# Altium schematic sheet DC-Motor-DRV.SchDoc(DC-Motor-DRV)
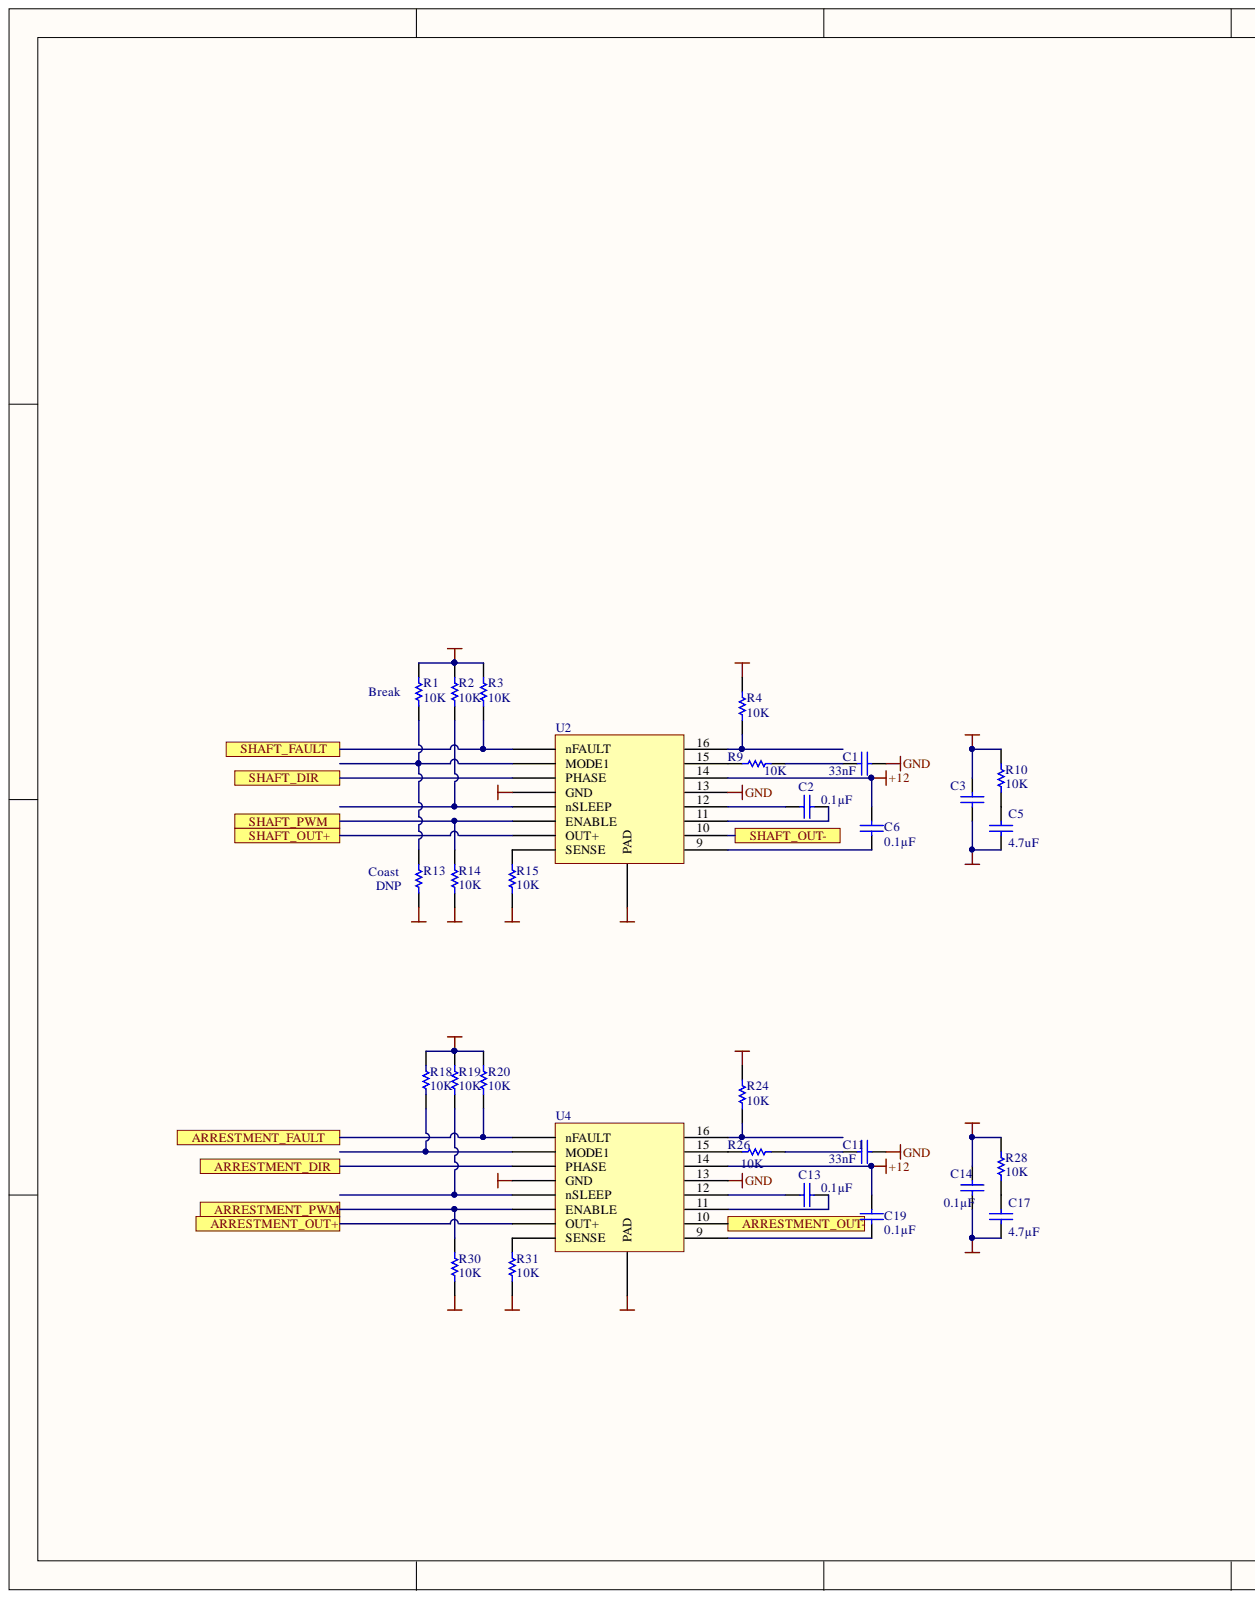
[diagram p0: DC-Motor-DRV.SchDoc, left]
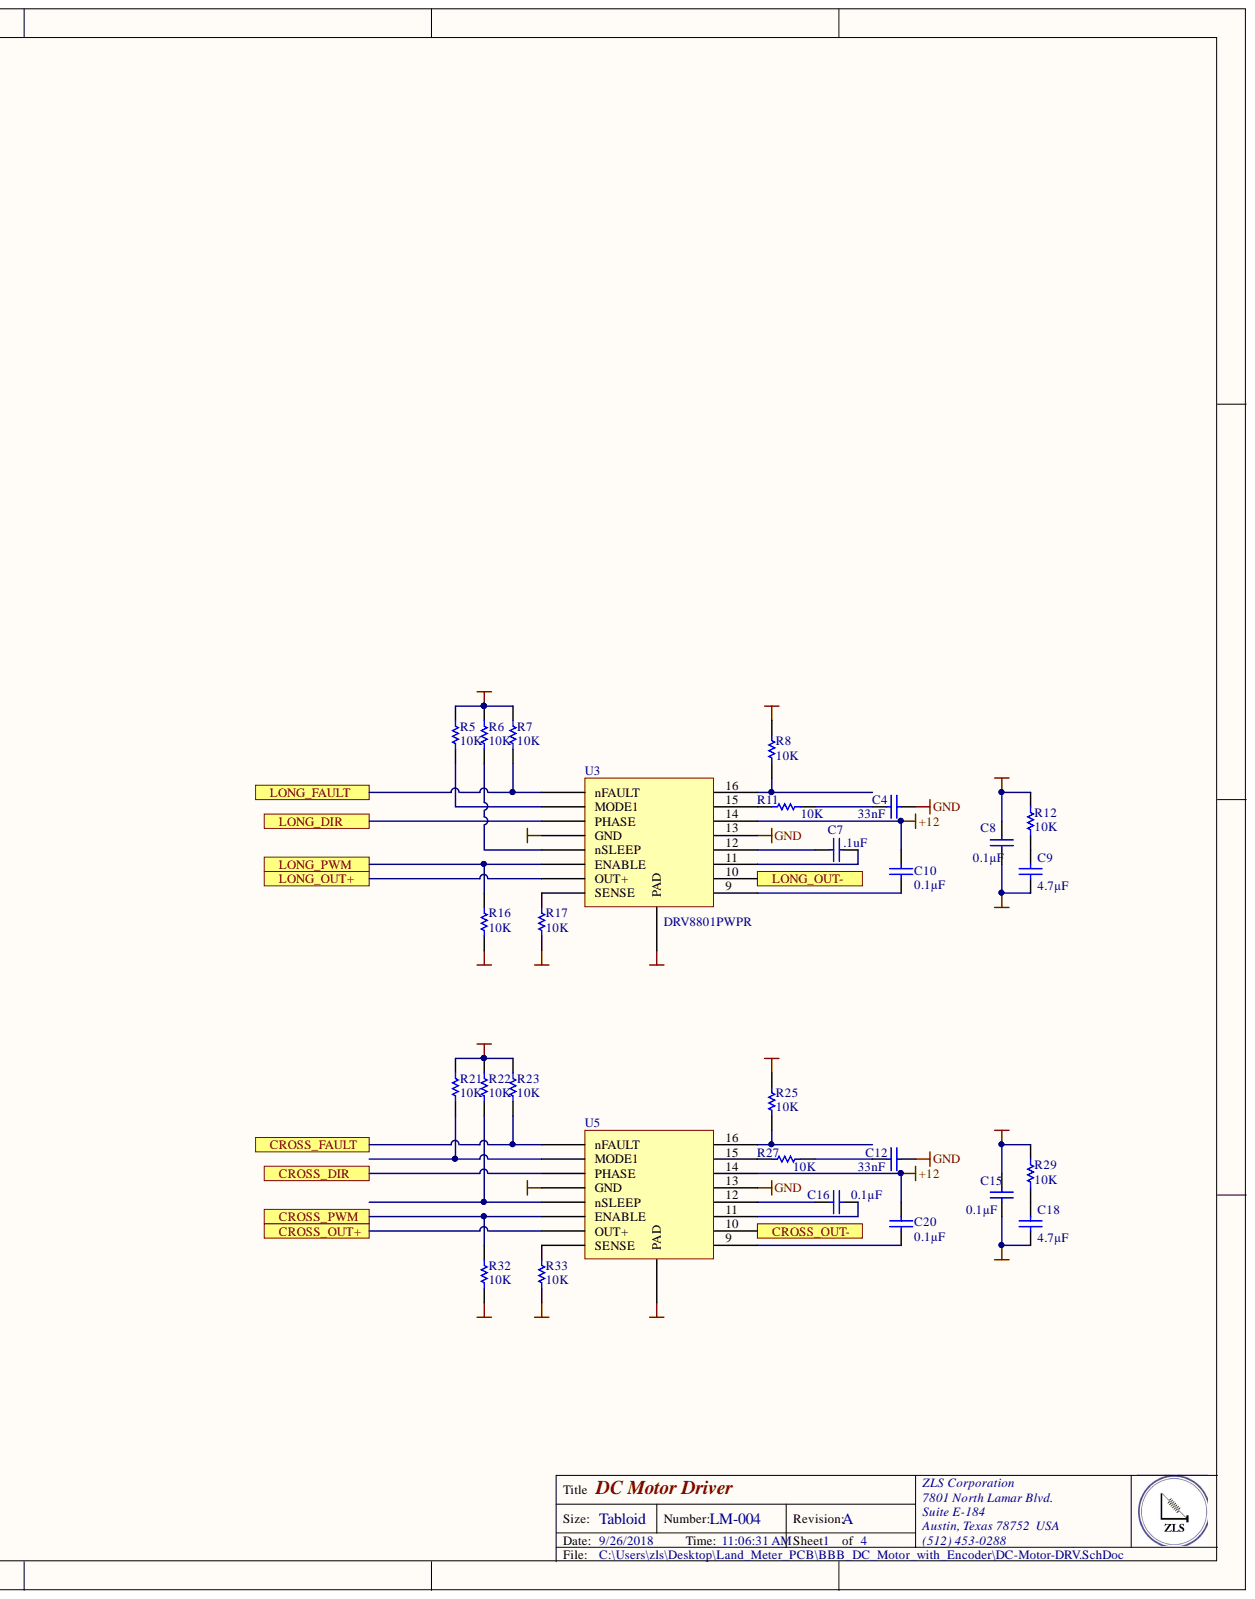
[diagram p1: DC-Motor-DRV.SchDoc, right]

## Parts
C1  33nF  [CAPC0402(1005)60_L]
C2  Capacitance: 0.1µF  [CAPC0805(2012)145_N]  (hidden comment: 0.1uF)
C3  0.1uF  [CAPC0805(2012)145_N]  (value not drawn)
C4  33nF  [CAPC0402(1005)60_L]
C5  4.7uF  [CAPC0805(2012)145_N]
C6  Capacitance: 0.1µF  [CAPC0805(2012)145_N]  (hidden comment: 0.1uF)
C7  .1uF  [CAPC0402(1005)60_N]
C8  Capacitance: 0.1µF  [CAPC0805(2012)145_N]  (hidden comment: .1uF)
C9  Capacitance: 4.7µF  [CAPC0805(2012)145_N]  (hidden comment: 4.7uF)
C10  .1uF  Capacitance: 0.1µF  [CAPC0805(2012)145_N]
C11  33nF  [CAPC0402(1005)60_L]
C12  33nF  [CAPC0402(1005)60_L]
C13  Capacitance: 0.1µF  [CAPC0805(2012)145_N]  (hidden comment: CAP CER 0.1UF 25V X7R 0805)
C14  Capacitance: 0.1µF  [CAPC0805(2012)145_N]  (hidden comment: CAP CER 0.1UF 25V X7R 0805)
C15  Capacitance: 0.1µF  [CAPC0805(2012)145_N]  (hidden comment: 0.1uF)
C16  Capacitance: 0.1µF  [CAPC0805(2012)145_N]  (hidden comment: 0.1uF)
C17  Capacitance: 4.7µF  [CAPC0805(2012)145_N]  (hidden comment: CAP CER 0.1UF 25V X7R 0805)
C18  Capacitance: 4.7µF  [CAPC0805(2012)145_N]  (hidden comment: 4.7uF)
C19  Capacitance: 0.1µF  [CAPC0805(2012)145_N]  (hidden comment: CAP CER 0.1UF 25V X7R 0805)
C20  Capacitance: 0.1µF  [CAPC0805(2012)145_N]  (hidden comment: 0.1uF)
R1  10K  [RESC0805(2012)_L]
R2  10K  [RESC0805(2012)_L]
R3  10K  [RESC0805(2012)_L]
R4  10K  [RESC0805(2012)_L]
R5  10K  [RESC0805(2012)_L]
R6  10K  [RESC0805(2012)_L]
R7  10K  [RESC0805(2012)_L]
R8  10K  [RESC0805(2012)_L]
R9  10K  [RESC0805(2012)_L]
R10  10K  [RESC0805(2012)_L]
R11  10K  [RESC0805(2012)_L]
R12  10K  [RESC0805(2012)_L]
R13  DNP  [RESC0805(2012)_L]
R14  10K  [RESC0805(2012)_L]
R15  10K  [RESC0805(2012)_L]
R16  10K  [RESC0805(2012)_L]
R17  10K  [RESC0805(2012)_L]
R18  10K  [RESC0805(2012)_L]
R19  10K  [RESC0805(2012)_L]
R20  10K  [RESC0805(2012)_L]
R21  10K  [RESC0805(2012)_L]
R22  10K  [RESC0805(2012)_L]
R23  10K  [RESC0805(2012)_L]
R24  10K  [RESC0805(2012)_L]
R25  10K  [RESC0805(2012)_L]
R26  10K  [RESC0805(2012)_L]
R27  10K  [RESC0805(2012)_L]
R28  10K  [RESC0805(2012)_L]
R29  10K  [RESC0805(2012)_L]
R30  10K  [RESC0805(2012)_L]
R31  10K  [RESC0805(2012)_L]
R32  10K  [RESC0805(2012)_L]
R33  10K  [RESC0805(2012)_L]
U2  DRV8801PWPR  [DRV8801PWPR]
U3  DRV8801PWPR  [DRV8801PWPR]
U4  DRV8801PWPR  [DRV8801PWPR]
U5  DRV8801PWPR  [DRV8801PWPR]

## Ports
ARRESTMENT_DIR, ARRESTMENT_FAULT, ARRESTMENT_OUT+, ARRESTMENT_OUT-, ARRESTMENT_PWM, CROSS_DIR, CROSS_FAULT, CROSS_OUT+, CROSS_OUT-, CROSS_PWM, LONG_DIR, LONG_FAULT, LONG_OUT+, LONG_OUT-, LONG_PWM, SHAFT_DIR, SHAFT_FAULT, SHAFT_OUT+, SHAFT_OUT-, SHAFT_PWM

## Nets
+3.3: R1.1 R2.1 R3.1 R4.1 R5.1 R6.1 R7.1 R8.1 R18.1 R19.1 R20.1 R21.1 R22.1 R23.1 R24.1 R25.1
+12: C3.1 C6.2 C8.1 C10.2 C14.1 C15.1 C19.2 C20.2 R10.1 R12.1 R28.1 R29.1 U2.14 U3.14 U4.14 U5.14
GND: C1.2 C3.2 C4.2 C5.2 C8.2 C9.2 C11.2 C12.2 C14.2 C15.2 C17.2 C18.2 R13.2 R14.2 R15.2 R16.2 R17.2 R30.2 R31.2 R32.2 R33.2 U2.4(GND) U2.13 U2.17(PAD) U3.4(GND) U3.13 U3.17(PAD) U4.4(GND) U4.13 U4.17(PAD) U5.4(GND) U5.13 U5.17(PAD)
ARRESTMENT_DIR: U4.3(PHASE) ; port ARRESTMENT_DIR
ARRESTMENT_FAULT: R20.2 U4.1(nFAULT) ; port ARRESTMENT_FAULT
ARRESTMENT_PWM: R30.1 U4.6(ENABLE) ; port ARRESTMENT_PWM
CROSS_DIR: U5.3(PHASE) ; port CROSS_DIR
CROSS_FAULT: R23.2 U5.1(nFAULT) ; port CROSS_FAULT
CROSS_PWM: R32.1 U5.6(ENABLE) ; port CROSS_PWM
LONG_DIR: U3.3(PHASE) ; port LONG_DIR
LONG_FAULT: R7.2 U3.1(nFAULT) ; port LONG_FAULT
LONG_PWM: R16.1 U3.6(ENABLE) ; port LONG_PWM
SHAFT_DIR: U2.3(PHASE) ; port SHAFT_DIR
SHAFT_FAULT: R3.2 U2.1(nFAULT) ; port SHAFT_FAULT
SHAFT_PWM: R14.1 U2.6(ENABLE) ; port SHAFT_PWM
NetC1_1: C1.1 R9.1
NetC2_1: C2.1 U2.12
NetC2_2: C2.2 U2.11
NetC4_1: C4.1 R11.1
NetC5_1: C5.1 R10.2
NetC6_1: C6.1 U2.9
NetC7_1: C7.1 U3.12
NetC7_2: C7.2 U3.11
NetC9_1: C9.1 R12.2
NetC10_1: C10.1 U3.9
NetC11_1: C11.1 R26.1
NetC12_1: C12.1 R27.1
NetC13_1: C13.1 U4.12
NetC13_2: C13.2 U4.11
NetC16_1: C16.1 U5.12
NetC16_2: C16.2 U5.11
NetC17_1: C17.1 R28.2
NetC18_1: C18.1 R29.2
NetC19_1: C19.1 U4.9
NetC20_1: C20.1 U5.9
NetR1_2: R1.2 R13.1 U2.2(MODE1)
NetR2_2: R2.2 U2.5(nSLEEP)
NetR4_2: R4.2 U2.16
NetR5_2: R5.2 U3.2(MODE1)
NetR6_2: R6.2 U3.5(nSLEEP)
NetR8_2: R8.2 U3.16
NetR9_2: R9.2 U2.15
NetR11_2: R11.2 U3.15
NetR15_1: R15.1 U2.8(SENSE)
NetR17_1: R17.1 U3.8(SENSE)
NetR18_2: R18.2 U4.2(MODE1)
NetR19_2: R19.2 U4.5(nSLEEP)
NetR21_2: R21.2 U5.2(MODE1)
NetR22_2: R22.2 U5.5(nSLEEP)
NetR24_2: R24.2 U4.16
NetR25_2: R25.2 U5.16
NetR26_2: R26.2 U4.15
NetR27_2: R27.2 U5.15
NetR31_1: R31.1 U4.8(SENSE)
NetR33_1: R33.1 U5.8(SENSE)
NetU2_7: U2.7(OUT+)
NetU2_10: U2.10
NetU3_7: U3.7(OUT+)
NetU3_10: U3.10
NetU4_7: U4.7(OUT+)
NetU4_10: U4.10
NetU5_7: U5.7(OUT+)
NetU5_10: U5.10

## Library data of the parts
C1 C11 = CMP-1034-00252-1: CAP CER 0.1UF 10V X7R 0402; Manufacturer Part Number: GRM155R71A104KA01D; Manufacturer: Murata Electronics North America; Tolerance: ±10%; Voltage - Rated: 10V; Thickness (Max): 0.022" (0.55mm); Temperature Coefficient: X7R; Supplier Part Number 1: 490-6321-1-ND; Supplier 1: Digi-Key; Stock 1: 2920395; Size / Dimension: 0.039" L x 0.020" W (1.00mm x 0.50mm); RoHS: RoHS Compliant; Ratings: -; Pricing 1: 1=0.1, 10=0.014, 100=0.0063, 500=0.00446, 1000=0.00351, 2500=0.00319, 5000=0.00293 (USD); Part Status: Active; Packaging: Cut Tape (CT); Package / Case: 0402 (1005 Metric); Operating Temperature: -55°C ~ 125°C; Mounting Type: Surface Mount, MLCC; Library Name: Altium Content Vault; Lead Spacing: -; Height - Seated (Max): -; Features: -; ComponentLink1URL: http://www.murata.com/~/media/webrenewal/support/library/catalog/products/capacitor/mlcc/c02e.ashx?la=en-us; ComponentLink1Description: http://www.murata.com/~/media/webrenewal/support/library/catalog/products/capacitor/mlcc/c02e.ashx?la=en-us; Component Kind: Standard; Category: Capacitors; Applications: General Purpose
C2 C6 C8 C10 C15 C16 C20 = CMP-1036-04795-1: CAP CER 0.1UF 25V X7R 0805; Manufacturer Part Number: GRM21BR71E104KA01L; Manufacturer: Murata Electronics North America; Tolerance: ±10%; Voltage - Rated: 25V; Thickness (Max): 0.053" (1.35mm); Temperature Coefficient: X7R; Supplier Part Number 1: 490-1673-6-ND; Supplier 1: Digi-Key; Stock 1: 891652; Size / Dimension: 0.079" L x 0.049" W (2.00mm x 1.25mm); RoHS: RoHS Compliant; Ratings: -; Pricing 1: 1=0.2, 10=0.145, 100=0.0683, 500=0.04788, 1000=0.03964 (USD); Part Status: Active; Packaging: Digi-Reel®; Package / Case: 0805 (2012 Metric); Operating Temperature: -55°C ~ 125°C; Mounting Type: Surface Mount, MLCC; Library Name: Altium Content Vault; Lead Style: -; Lead Spacing: -; Height - Seated (Max): -; Features: -; ComponentLink1URL: http://www.murata.com/~/media/webrenewal/support/library/catalog/products/capacitor/mlcc/c02e.ashx; ComponentLink1Description: http://www.murata.com/~/media/webrenewal/support/library/catalog/products/capacitor/mlcc/c02e.ashx; Component Kind: Standard; Category: Capacitors; Applications: General Purpose
C3 = CMP-1036-04795-1: CAP CER 0.1UF 25V X7R 0805; Manufacturer Part Number: GRM21BR71E104KA01L; Manufacturer: Murata Electronics North America; Tolerance: ±10%; Capacitance: 0.1µF; Voltage - Rated: 25V; Thickness (Max): 0.053" (1.35mm); Temperature Coefficient: X7R; Supplier Part Number 1: 490-1673-6-ND; Supplier 1: Digi-Key; Stock 1: 891652; Size / Dimension: 0.079" L x 0.049" W (2.00mm x 1.25mm); RoHS: RoHS Compliant; Ratings: -; Pricing 1: 1=0.2, 10=0.145, 100=0.0683, 500=0.04788, 1000=0.03964 (USD); Part Status: Active; Packaging: Digi-Reel®; Package / Case: 0805 (2012 Metric); Operating Temperature: -55°C ~ 125°C; Mounting Type: Surface Mount, MLCC; Library Name: Altium Content Vault; Lead Style: -; Lead Spacing: -; Height - Seated (Max): -; Features: -; ComponentLink1URL: http://www.murata.com/~/media/webrenewal/support/library/catalog/products/capacitor/mlcc/c02e.ashx; ComponentLink1Description: http://www.murata.com/~/media/webrenewal/support/library/catalog/products/capacitor/mlcc/c02e.ashx; Component Kind: Standard; Category: Capacitors; Applications: General Purpose
C4 = CMP-1034-00252-1: CAP CER 33UF 10V X7R 0402; Manufacturer Part Number: GRM155R71A104KA01D; Manufacturer: Murata Electronics North America; Tolerance: ±10%; Voltage - Rated: 10V; Thickness (Max): 0.022" (0.55mm); Temperature Coefficient: X7R; Supplier Part Number 1: 490-6321-1-ND; Supplier 1: Digi-Key; Stock 1: 2920395; Size / Dimension: 0.039" L x 0.020" W (1.00mm x 0.50mm); RoHS: RoHS Compliant; Ratings: -; Pricing 1: 1=0.1, 10=0.014, 100=0.0063, 500=0.00446, 1000=0.00351, 2500=0.00319, 5000=0.00293 (USD); Part Status: Active; Packaging: Cut Tape (CT); Package / Case: 0402 (1005 Metric); Operating Temperature: -55°C ~ 125°C; Mounting Type: Surface Mount, MLCC; Library Name: Altium Content Vault; Lead Spacing: -; Height - Seated (Max): -; Features: -; ComponentLink1URL: http://www.murata.com/~/media/webrenewal/support/library/catalog/products/capacitor/mlcc/c02e.ashx?la=en-us; ComponentLink1Description: http://www.murata.com/~/media/webrenewal/support/library/catalog/products/capacitor/mlcc/c02e.ashx?la=en-us; Component Kind: Standard; Category: Capacitors; Applications: General Purpose
C5 = CMP-1036-04795-1: CAP CER 4.7UF 25V 10% X7R 0805; Manufacturer Part Number: GRM21BR71E104KA01L; Manufacturer: Murata Electronics North America; Capacitance: 4.7µF; Voltage - Rated: 25V; Tolerance: ±10%; Thickness (Max): 0.053" (1.35mm); Temperature Coefficient: X7R; Supplier Part Number 1: 490-1673-6-ND; Supplier 1: Digi-Key; Stock 1: 891652; Size / Dimension: 0.079" L x 0.049" W (2.00mm x 1.25mm); RoHS: RoHS Compliant; Ratings: -; Pricing 1: 1=0.2, 10=0.145, 100=0.0683, 500=0.04788, 1000=0.03964 (USD); Part Status: Active; Packaging: Digi-Reel®; Package / Case: 0805 (2012 Metric); Operating Temperature: -55°C ~ 125°C; Mounting Type: Surface Mount, MLCC; Library Name: Altium Content Vault; Lead Style: -; Lead Spacing: -; Height - Seated (Max): -; Features: -; ComponentLink1URL: http://www.murata.com/~/media/webrenewal/support/library/catalog/products/capacitor/mlcc/c02e.ashx; ComponentLink1Description: http://www.murata.com/~/media/webrenewal/support/library/catalog/products/capacitor/mlcc/c02e.ashx; Component Kind: Standard; Category: Capacitors; Applications: General Purpose
C7 = CMP-1034-01622-1: CAP 1nF 25V ±10% 0402 (1005 Metric) Thickness 0.6mm SMD; Tolerance: Â±10%; Case-Metric: 1005; Case-EIA: 0402; Value: 1nF; Technology: SMT; Rated Voltage: 25 V; Max Thickness: 0.6 mm; Library Name: Altium Content Vault; Component Kind: Standard
C9 C18 = CMP-1036-04795-1: CAP CER 4.7UF 25V X7R 0805; Manufacturer Part Number: GRM21BR71E104KA01L; Manufacturer: Murata Electronics North America; Tolerance: ±10%; Voltage - Rated: 25V; Thickness (Max): 0.053" (1.35mm); Temperature Coefficient: X7R; Supplier Part Number 1: 490-1673-6-ND; Supplier 1: Digi-Key; Stock 1: 891652; Size / Dimension: 0.079" L x 0.049" W (2.00mm x 1.25mm); RoHS: RoHS Compliant; Ratings: -; Pricing 1: 1=0.2, 10=0.145, 100=0.0683, 500=0.04788, 1000=0.03964 (USD); Part Status: Active; Packaging: Digi-Reel®; Package / Case: 0805 (2012 Metric); Operating Temperature: -55°C ~ 125°C; Mounting Type: Surface Mount, MLCC; Library Name: Altium Content Vault; Lead Style: -; Lead Spacing: -; Height - Seated (Max): -; Features: -; ComponentLink1URL: http://www.murata.com/~/media/webrenewal/support/library/catalog/products/capacitor/mlcc/c02e.ashx; ComponentLink1Description: http://www.murata.com/~/media/webrenewal/support/library/catalog/products/capacitor/mlcc/c02e.ashx; Component Kind: Standard; Category: Capacitors; Applications: General Purpose
C12 = CMP-1034-00252-1: CAP CER 3.3UF 10V X7R 0402; Manufacturer Part Number: GRM155R71A104KA01D; Manufacturer: Murata Electronics North America; Tolerance: ±10%; Voltage - Rated: 10V; Thickness (Max): 0.022" (0.55mm); Temperature Coefficient: X7R; Supplier Part Number 1: 490-6321-1-ND; Supplier 1: Digi-Key; Stock 1: 2920395; Size / Dimension: 0.039" L x 0.020" W (1.00mm x 0.50mm); RoHS: RoHS Compliant; Ratings: -; Pricing 1: 1=0.1, 10=0.014, 100=0.0063, 500=0.00446, 1000=0.00351, 2500=0.00319, 5000=0.00293 (USD); Part Status: Active; Packaging: Cut Tape (CT); Package / Case: 0402 (1005 Metric); Operating Temperature: -55°C ~ 125°C; Mounting Type: Surface Mount, MLCC; Library Name: Altium Content Vault; Lead Spacing: -; Height - Seated (Max): -; Features: -; ComponentLink1URL: http://www.murata.com/~/media/webrenewal/support/library/catalog/products/capacitor/mlcc/c02e.ashx?la=en-us; ComponentLink1Description: http://www.murata.com/~/media/webrenewal/support/library/catalog/products/capacitor/mlcc/c02e.ashx?la=en-us; Component Kind: Standard; Category: Capacitors; Applications: General Purpose
C13 C14 C19 = CMP-1036-04795-1: Manufacturer Part Number: GRM21BR71E104KA01L; Manufacturer: Murata Electronics North America; Tolerance: ±10%; Voltage - Rated: 25V; Thickness (Max): 0.053" (1.35mm); Temperature Coefficient: X7R; Supplier Part Number 1: 490-1673-6-ND; Supplier 1: Digi-Key; Stock 1: 891652; Size / Dimension: 0.079" L x 0.049" W (2.00mm x 1.25mm); RoHS: RoHS Compliant; Ratings: -; Pricing 1: 1=0.2, 10=0.145, 100=0.0683, 500=0.04788, 1000=0.03964 (USD); Part Status: Active; Packaging: Digi-Reel®; Package / Case: 0805 (2012 Metric); Operating Temperature: -55°C ~ 125°C; Mounting Type: Surface Mount, MLCC; Library Name: Altium Content Vault; Lead Style: -; Lead Spacing: -; Height - Seated (Max): -; Features: -; Description: CAP CER 0.1UF 25V X7R 0805; ComponentLink1URL: http://www.murata.com/~/media/webrenewal/support/library/catalog/products/capacitor/mlcc/c02e.ashx; ComponentLink1Description: http://www.murata.com/~/media/webrenewal/support/library/catalog/products/capacitor/mlcc/c02e.ashx; Component Kind: Standard; Category: Capacitors; Applications: General Purpose
C17 = CMP-1036-04795-1: CAP CER 0.47UF 25V X7R 0805; Manufacturer Part Number: GRM21BR71E104KA01L; Manufacturer: Murata Electronics North America; Tolerance: ±10%; Voltage - Rated: 25V; Thickness (Max): 0.053" (1.35mm); Temperature Coefficient: X7R; Supplier Part Number 1: 490-1673-6-ND; Supplier 1: Digi-Key; Stock 1: 891652; Size / Dimension: 0.079" L x 0.049" W (2.00mm x 1.25mm); RoHS: RoHS Compliant; Ratings: -; Pricing 1: 1=0.2, 10=0.145, 100=0.0683, 500=0.04788, 1000=0.03964 (USD); Part Status: Active; Packaging: Digi-Reel®; Package / Case: 0805 (2012 Metric); Operating Temperature: -55°C ~ 125°C; Mounting Type: Surface Mount, MLCC; Library Name: Altium Content Vault; Lead Style: -; Lead Spacing: -; Height - Seated (Max): -; Features: -; ComponentLink1URL: http://www.murata.com/~/media/webrenewal/support/library/catalog/products/capacitor/mlcc/c02e.ashx; ComponentLink1Description: http://www.murata.com/~/media/webrenewal/support/library/catalog/products/capacitor/mlcc/c02e.ashx; Component Kind: Standard; Category: Capacitors; Applications: General Purpose
R1-R9 R11 R13-R27 R30-R33 = CMP-1013-00215-1: RES SMD 10K OHM 1% 1/8W 0805; Manufacturer Part Number: CRCW080510K0FKEA; Manufacturer: Vishay Dale; Temperature Coefficient: ±100ppm/°C; Power (Watts): 0.125W, 1/8W; Tolerance: ±1%; Supplier Part Number 1: 541-10.0KCCT-ND; Supplier Device Package: 0805; Supplier 1: Digi-Key; Stock 1: 1104982; Size / Dimension: 0.079" L x 0.049" W (2.00mm x 1.25mm); RoHS: RoHS Compliant; Pricing 1: 1=0.1, 10=0.055, 100=0.0223, 1000=0.01003, 2500=0.0087 (USD); Part Status: Active; Packaging: Cut Tape (CT); Package / Case: 0805 (2012 Metric); Operating Temperature: -55°C ~ 155°C; Library Name: Altium Content Vault; Height - Seated (Max): 0.020" (0.50mm); Features: Automotive AEC-Q200; Failure Rate: -; Composition: Thick Film; ComponentLink1URL: http://www.vishay.com/docs/20035/dcrcwe3.pdf; ComponentLink1Description: http://www.vishay.com/docs/20035/dcrcwe3.pdf; Component Kind: Standard; Category: Resistors
R10 R12 R28 R29 = CMP-1013-00215-1: RES SMD 1R OHM 1% 1/8W 0805; Manufacturer Part Number: CRCW080510K0FKEA; Manufacturer: Vishay Dale; Temperature Coefficient: ±100ppm/°C; Power (Watts): 0.125W, 1/8W; Tolerance: ±1%; Supplier Part Number 1: 541-10.0KCCT-ND; Supplier Device Package: 0805; Supplier 1: Digi-Key; Stock 1: 1104982; Size / Dimension: 0.079" L x 0.049" W (2.00mm x 1.25mm); RoHS: RoHS Compliant; Pricing 1: 1=0.1, 10=0.055, 100=0.0223, 1000=0.01003, 2500=0.0087 (USD); Part Status: Active; Packaging: Cut Tape (CT); Package / Case: 0805 (2012 Metric); Operating Temperature: -55°C ~ 155°C; Library Name: Altium Content Vault; Height - Seated (Max): 0.020" (0.50mm); Features: Automotive AEC-Q200; Failure Rate: -; Composition: Thick Film; ComponentLink1URL: http://www.vishay.com/docs/20035/dcrcwe3.pdf; ComponentLink1Description: http://www.vishay.com/docs/20035/dcrcwe3.pdf; Component Kind: Standard; Category: Resistors
U2-U5 = DRV8801PWPR: IC MOTOR DRIVER PAR 16HTSSOP; Manufacturer: Texas Instruments; Voltage - Supply: 8 V ~ 38 V; Output Configuration: Half Bridge (2); Motor Type - Stepper: Bipolar; Motor Type - AC, DC: Brushed DC; Interface: Parallel; Function: Driver - Fully Integrated, Control and Power Stage; Current - Output: 2.8A; Voltage - Load: 8 V ~ 38 V; Technology: DMOS; Supplier Part Number 1: 296-25378-1-ND; Supplier Device Package: 16-HTSSOP; Supplier 1: Digi-Key; Stock 1: 44037; Step Resolution: -; RoHS: RoHS Compliant; Pricing 1: 1=2.11, 10=1.892, 100=1.5204, 500=1.24914, 1000=1.035 (USD); Part Status: Active; Packaging: Cut Tape (CT); Package / Case: 16-TSSOP (0.173", 4.40mm Width) Exposed Pad; Operating Temperature: -40°C ~ 150°C (TJ); Mounting Type: Surface Mount; Manufacturer Part Number: DRV8801PWPR; ComponentLink1URL: http://www.ti.com/lit/ds/symlink/drv8801.pdf; ComponentLink1Description: http://www.ti.com/lit/ds/symlink/drv8801.pdf; Component Kind: Standard; Category: Integrated Circuits (ICs); Base Part Number: DRV8801; Applications: General Purpose; Library Name: ZLS_Schematic_lib.SchLib
